# Altium schematic sheet 5_REG1.SchDoc
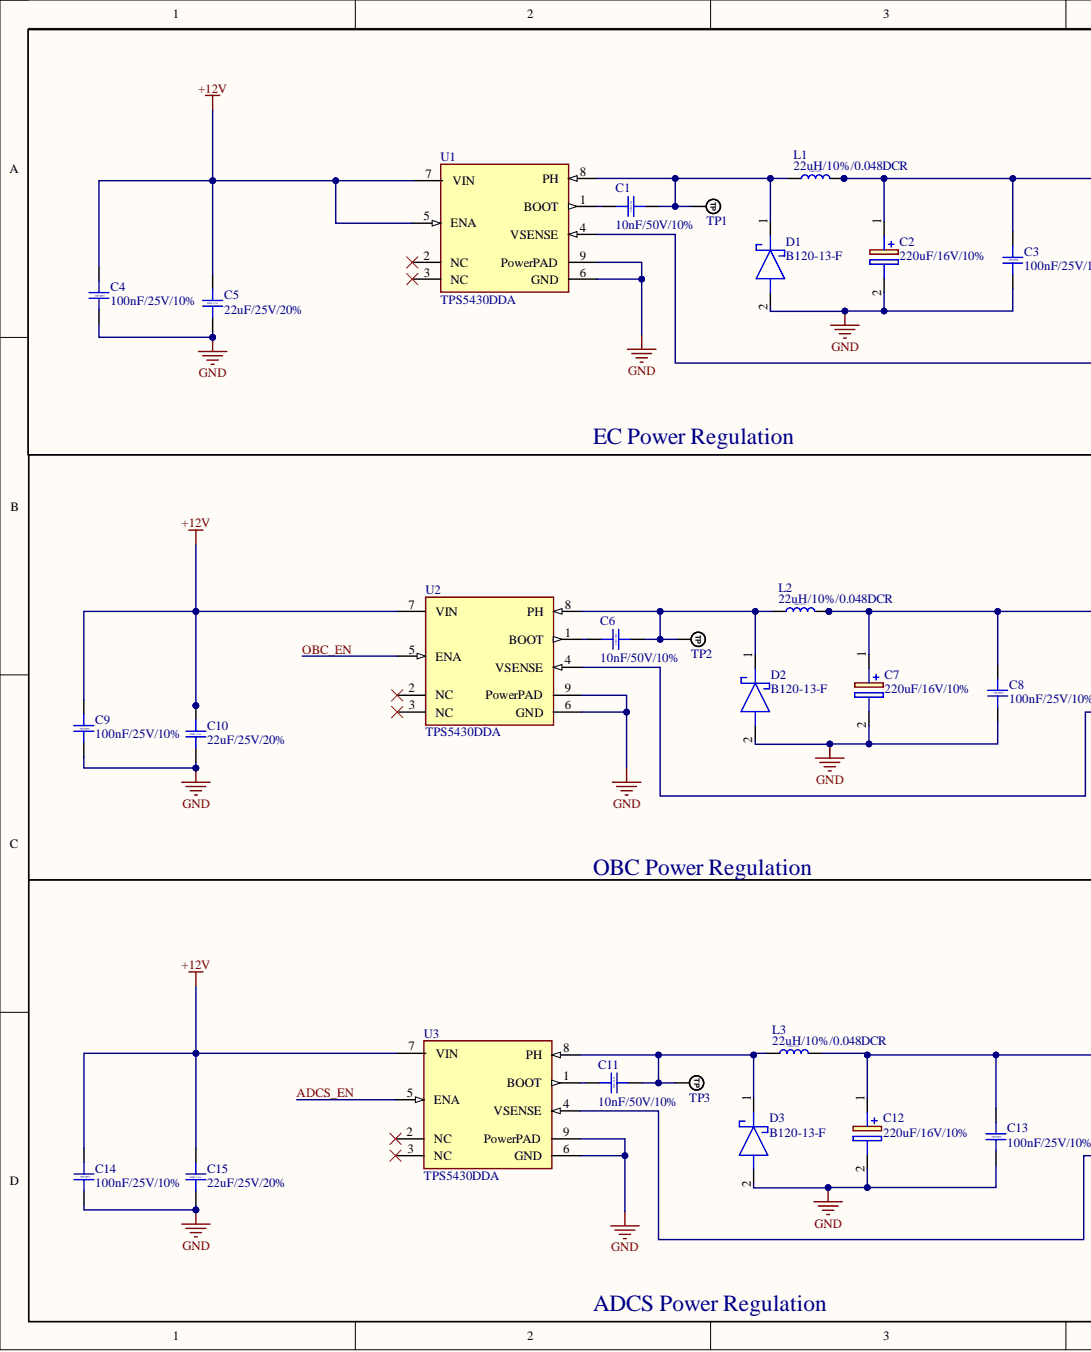
[diagram p0: 5_REG1.SchDoc, left]
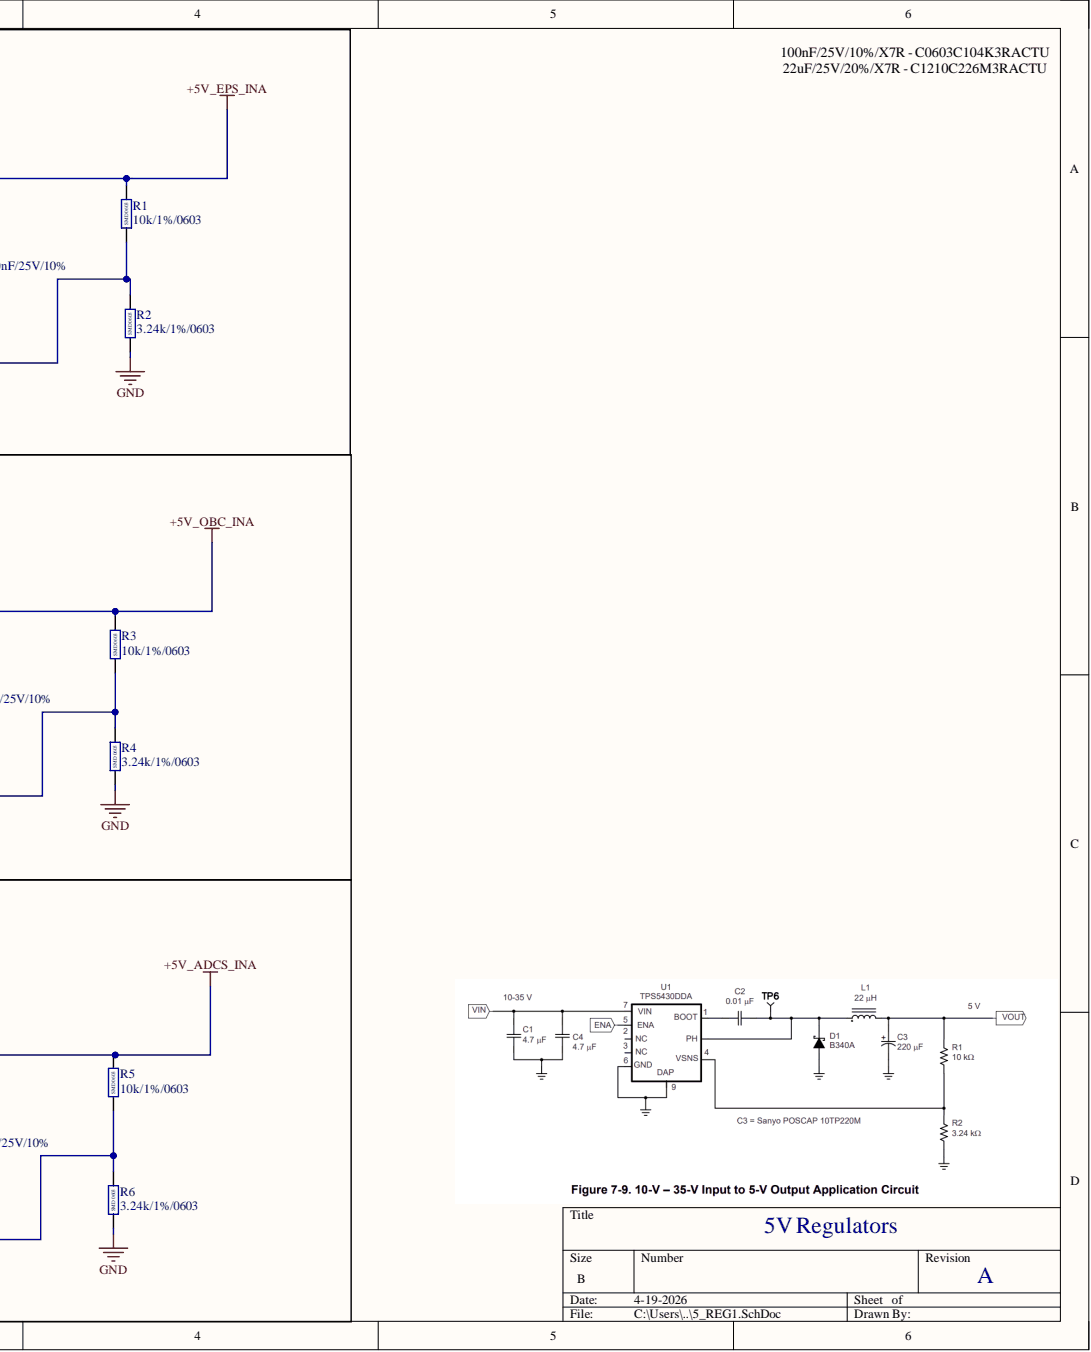
[diagram p1: 5_REG1.SchDoc, right]

## Parts
C1  10nF/50V/10%  [CAP_0402]
C2  220uF/16V/10%  [T491D]
C3  100nF/25V/10%  [CAP_0603]
C4  100nF/25V/10%  [CAP_0603]
C5  22uF/25V/20%  [CAP_1210]
C6  10nF/50V/10%  [CAP_0402]
C7  220uF/16V/10%  [T491D]
C8  100nF/25V/10%  [CAP_0603]
C9  100nF/25V/10%  [CAP_0603]
C10  22uF/25V/20%  [CAP_1210]
C11  10nF/50V/10%  [CAP_0402]
C12  220uF/16V/10%  [T491D]
C13  100nF/25V/10%  [CAP_0603]
C14  100nF/25V/10%  [CAP_0603]
C15  22uF/25V/20%  [CAP_1210]
D1  B120-13-F  [DIOM5226X240N]
D2  B120-13-F  [DIOM5226X240N]
D3  B120-13-F  [DIOM5226X240N]
L1  22uH/10%/0.048DCR  [BPSC00131380220M00]
L2  22uH/10%/0.048DCR  [BPSC00131380220M00]
L3  22uH/10%/0.048DCR  [BPSC00131380220M00]
R1  10k/1%/0603  [RES_0603]
R2  3.24k/1%/0603  [RES_0603]
R3  10k/1%/0603  [RES_0603]
R4  3.24k/1%/0603  [RES_0603]
R5  10k/1%/0603  [RES_0603]
R6  3.24k/1%/0603  [RES_0603]
TP1  TP_NOBOM  [PAD_RND_1MM]  (value not drawn)  (no BOM)
TP2  TP_NOBOM  [PAD_RND_1MM]  (value not drawn)  (no BOM)
TP3  TP_NOBOM  [PAD_RND_1MM]  (value not drawn)  (no BOM)
U1  TPS5430DDA  [FP-DDA0008J-MFG]
U2  TPS5430DDA  [FP-DDA0008J-MFG]
U3  TPS5430DDA  [FP-DDA0008J-MFG]

## Nets
+5V_ADCS_INA: C12.1 C13.2 L3.2
+5V_EPS_INA: C2.1 C3.2 L1.2 R1.2
+5V_OBC_INA: C7.1 C8.2 L2.2 R3.2
+12V: C4.2 C5.1 C9.2 C10.1 C14.2 C15.1 U1.5(ENA) U1.7(VIN) U2.7(VIN) U3.7(VIN)
GND: C2.2 C3.1 C4.1 C5.2 C7.2 C8.1 C9.1 C10.2 C12.2 C13.1 C14.1 C15.2 D1.2 D2.2 D3.2 R2.1 R4.1 R6.1 U1.6(GND) U1.9(PowerPAD) U2.6(GND) U2.9(PowerPAD) U3.6(GND) U3.9(PowerPAD)
ADCS_EN: U3.5(ENA)
OBC_EN: U2.5(ENA)
NetC1_1: C1.1 U1.1(BOOT)
NetC1_2: C1.2 D1.1 L1.1 TP1.1 U1.8(PH)
NetC6_1: C6.1 U2.1(BOOT)
NetC6_2: C6.2 D2.1 L2.1 TP2.1 U2.8(PH)
NetC11_1: C11.1 U3.1(BOOT)
NetC11_2: C11.2 D3.1 L3.1 TP3.1 U3.8(PH)
NetR1_1: R1.1 R2.2 U1.4(VSENSE)
NetR3_1: R3.1 R4.2 U2.4(VSENSE)
NetR5_1: R5.1 R6.2 U3.4(VSENSE)

Not connected: R5 2; U1 2(NC) 3(NC); U2 2(NC) 3(NC); U3 2(NC) 3(NC)

## Library data of the parts
C1 C6 C11 = Capacitor-SMD-0402: Capacitor SMD 0402 RATED; Component Kind: Standard; Library Name: STeRG-Passives-Standard.SchLib
C2 C7 C12 = T491D227K016AT: Manufacturer_Name: YAGEO; Arrow Part Number: T491D227K016AT; Arrow Price/Stock: https://www.arrow.com/en/products/t491d227k016at/kemet-corporation?region=nac; Component Kind: Standard; Datasheet Link: https://content.kemet.com/datasheets/KEM_T2005_T491.pdf; Description: T491D227K016AT; Height: 3.1mm; Manufacturer_Part_Number: T491D227K016AT; Mouser Part Number: 80-T491D227K016AT; Mouser Price/Stock: https://www.mouser.co.uk/ProductDetail/KEMET/T491D227K016AT?qs=wo4x%252BUeoG8UHz8vxfdkfAA%3D%3D
C3 C4 C8 C9 C13 C14 = Capacitor-SMD-0603: C0603C104K3RACTU; Component Kind: Standard; Library Name: STeRG-Passives-Standard.SchLib
C5 C10 C15 = Capacitor-SMD-1210: C1210C226M3RACTU; Component Kind: Standard; Library Name: STeRG-Passives-Standard.SchLib
D1-D3 = B120-13-F: Schottky Diode; Manufacturer_Name: Diodes Incorporated; Arrow Part Number: B120-13-F; Arrow Price/Stock: https://www.arrow.com/en/products/b120-13-f/diodes-incorporated?region=nac; Component Kind: Standard; Datasheet Link: https://www.diodes.com/assets/Datasheets/ds13002.pdf; Height: 2.4mm; Manufacturer_Part_Number: B120-13-F; Mouser Part Number: 621-B120-F; Mouser Price/Stock: https://www.mouser.co.uk/ProductDetail/Diodes-Incorporated/B120-13-F?qs=m%2FDAVSu2JKQ2m%2FldqYkj8Q%3D%3D; Library Name: STeRG-Passives-Standard.SchLib
L1-L3 = Inductor-SMD-RF-1008: BPSC00131380220M00; Component Kind: Standard; Library Name: STeRG-Passives-Standard.SchLib
R1 R3 R5 = Resistor-SMD-0603: CRCW060310K0FKEA; Component Kind: Standard; Library Name: STeRG-Passives-Standard.SchLib
R2 R4 R6 = Resistor-SMD-0603: CRCW06033K24FKEA; Component Kind: Standard; Library Name: STeRG-Passives-Standard.SchLib
TP1-TP3 = TEST_POINT_RND_1MM: Test Point Round 1mm (NO BOM); Library Name: STeRG-Passives-Standard.SchLib
U1-U3 = CMP-2000-04960-2: IC REG BUCK ADJUSTABLE 3A 8SOPWR; Manufacturer: Texas Instruments; Max Duty Cycle: 89%; Max Junction Temp: +125°C; Max Supply Voltage: 36V; Min Supply Voltage: 5.5V; Nominal Supply Current: 3mA; Number Of Outputs: 1; Output Type: AdjustableProgrammable; Output Voltage: 1.23-31V; Standoff Height: 0mm; Switching Frequency: 500kHz; Automotive: No; Category: IC; Component Kind: Standard; ComponentLink2Description: Datasheet; ComponentLink2URL: http://www.ti.com/lit/ds/symlink/tps5431.pdf; Device Class L1: Integrated Circuits (ICs); Device Class L2: Power Management ICs; Device Class L3: Voltage Regulators - Switching; Digikey Description: IC REG BUCK ADJUSTABLE 3A 8SOPWR; Height: 1.7mm; Lead Free: Yes; Library Name: Altium Content Vault; Manufacturer Part Number: TPS5430DDA; Mouser Description: Voltage Regulators - Switching Regulators 5.5 to 36V Input 3A Step Dwn Converter; Octopart: https://octopart.com/tps5430dda-texas+instruments-589977; Output Current: 3A; Package: DDA0008J; RoHS: TRUE; Switching Topology: Buck; Temperature: -40°C to +125°C; Temperature Range High: +125°C; Temperature Range Low: -40°C
